# Altium schematic sheet Bluetooth.SchDoc
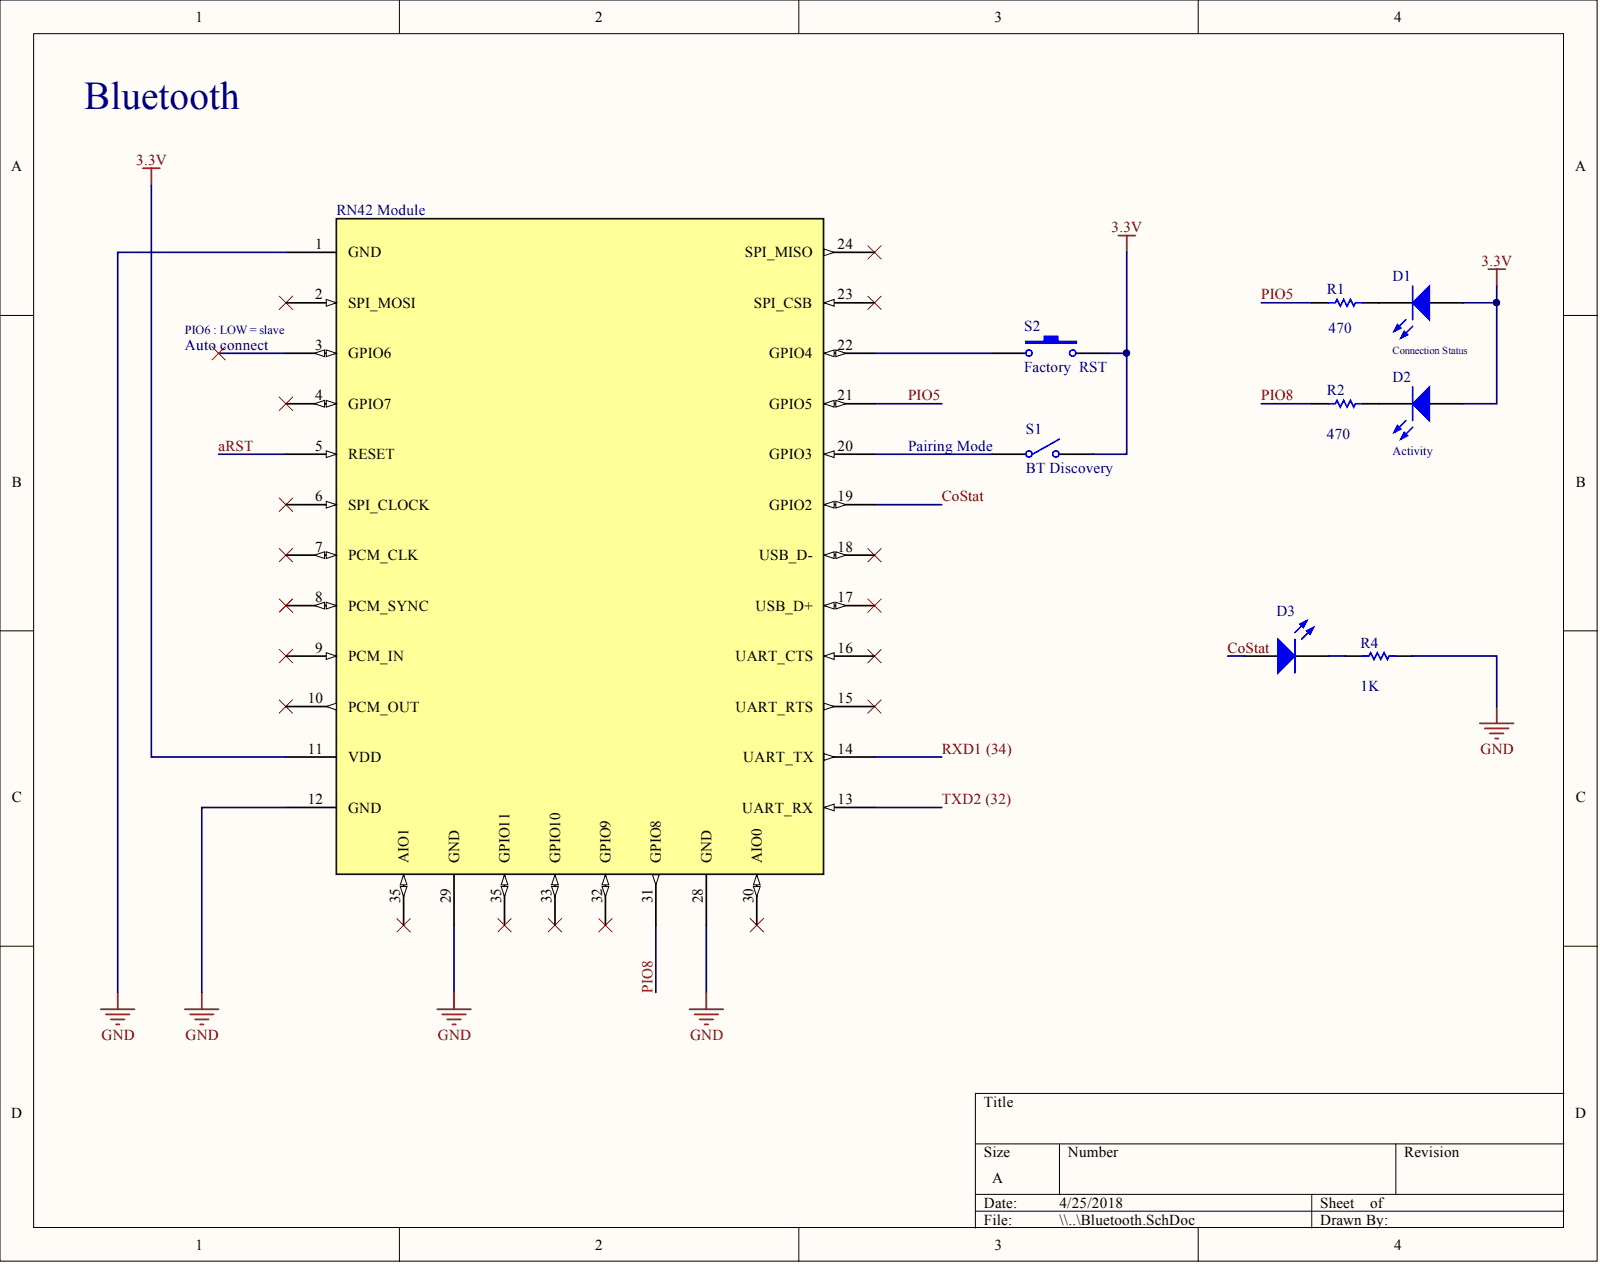
[diagram p0: Bluetooth.SchDoc]

## Parts
D1  Connection Status  [LED-0]
D2  Activity  [LED-0]
D3  LED  [LED-0]  (value not drawn)
R1  value 470  [AXIAL-0.3]  (hidden comment: Resistor 1)
R2  value 470  [AXIAL-0.3]  (hidden comment: Resistor 2)
R4  value 1K  [AXIAL-0.3]  (hidden comment: Res1)
RN42_Module  RN42
S1  BT Discovery  [SPST-2]
S2  Factory  RST  [SPST-2]

## Nets
3.3V: D1.1 D2.1 RN42_Module.11(VDD) S1.2 S2.2
GND: R4.1 RN42_Module.1(GND) RN42_Module.12(GND) RN42_Module.28(GND) RN42_Module.29(GND)
ARST: RN42_Module.5(RESET)
COSTAT: D3.1 RN42_Module.19(GPIO2)
PIO5: R1.1 RN42_Module.21(GPIO5)
PIO8: R2.1 RN42_Module.31(GPIO8)
RXD1 (34): RN42_Module.14(UART_TX)
TXD2 (32): RN42_Module.13(UART_RX)
NetD1_2: D1.2 R1.2
NetD2_2: D2.2 R2.2
NetD3_2: D3.2 R4.2
NetRN42 Module_20: RN42_Module.20(GPIO3) S1.1
NetRN42 Module_22: RN42_Module.22(GPIO4) S2.1

Not connected: RN42_Module 2(SPI_MOSI) 3(GPIO6) 4(GPIO7) 6(SPI_CLOCK) 7(PCM_CLK) 8(PCM_SYNC) 9(PCM_IN) 10(PCM_OUT) 15(UART_RTS) 16(UART_CTS) 17(USB_D+) 18(USB_D-) 23(SPI_CSB) 24(SPI_MISO) 30(AIO0) 32(GPIO9) 33(GPIO10) 35(AIO1)

## Library data of the parts
D1-D3 = LED0: Typical INFRARED GaAs LED; Component Kind: Standard; LatestRevisionDate: 08-Jul-2005; LatestRevisionNote: Mech Layer 1 removed.; Library Name: Miscellaneous Devices.IntLib; PackageDescription: LED; 2 Leads; PackageReference: LED-0; Published: 8-Jun-2000; Simulation: LED0; Publisher: Altium Limited
R1 R2 R4 = Res1: Resistor; Component Kind: Standard; LatestRevisionDate: 17-Jul-2002; LatestRevisionNote: Re-released for DXP Platform.; Library Name: Miscellaneous Devices.IntLib; PackageDescription: Axial Device, Thru-Hole; 2 Leads; 0.3 in Pin Spacing; PackageReference: AXIAL-0.3; Published: 8-Jun-2000; Signal Integrity: Res; Simulation: RESISTOR; Publisher: Altium Limited
S1 = SW-SPST: Single-Pole, Single-Throw Switch; Component Kind: Standard; LatestRevisionDate: 17-Jul-2002; LatestRevisionNote: Re-released for DXP Platform.; Library Name: Miscellaneous Devices.IntLib; PackageDescription: Switch; 2 Leads; PackageReference: SPST-2; Published: 24-Mar-1999; Publisher: Altium Limited
S2 = SW-PB: Switch; Component Kind: Standard; LatestRevisionDate: 17-Jul-2002; LatestRevisionNote: Re-released for DXP Platform.; Library Name: Miscellaneous Devices.IntLib; PackageDescription: Switch; 2 Leads; PackageReference: SPST-2; Published: 24-Mar-1999; Publisher: Altium Limited
RN42_Module = RN42: Component Kind: Standard; Library Name: Schlib.SchLib
